# Altium schematic sheet Debug.SchDoc(Debug)
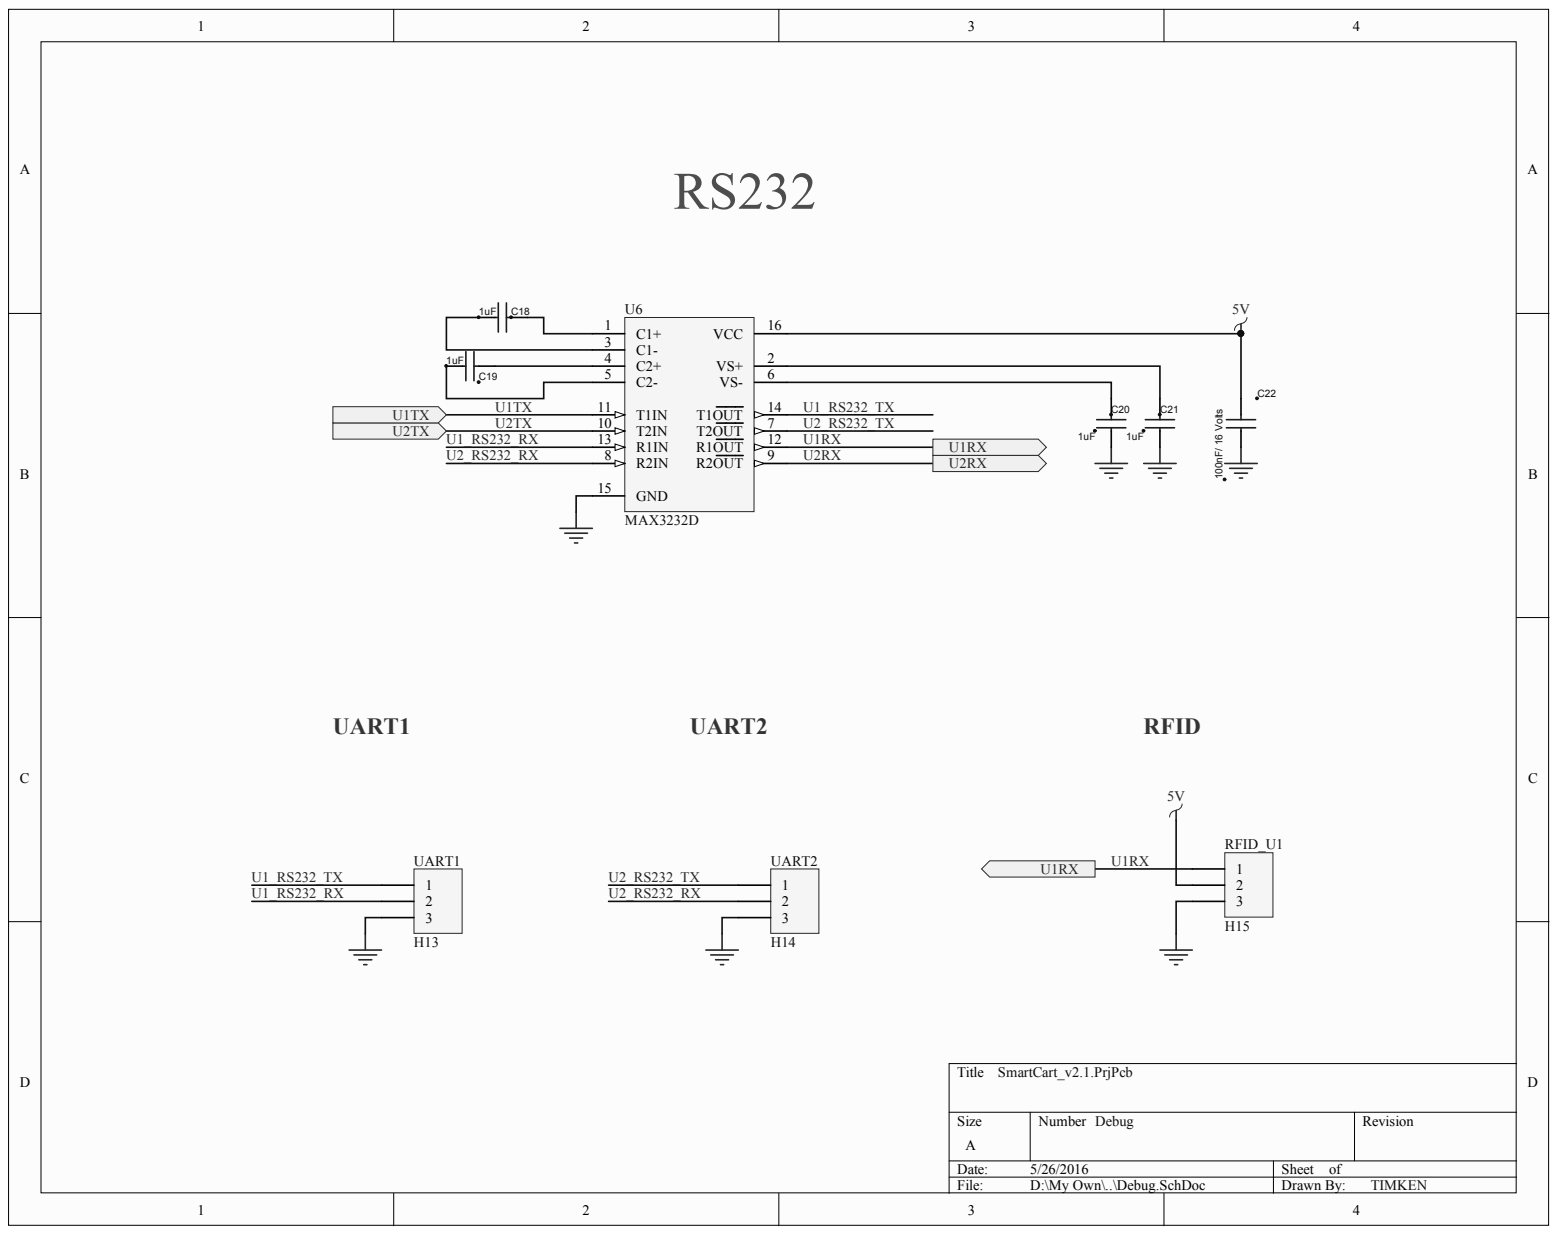
[diagram p0: Debug.SchDoc]

## Parts
C18  1uF  [C0805]
C19  1uF  [C0805]
C20  1uF  [C0805]
C21  1uF  [C0805]
C22  100nF/ 16 Volts  [C0805]
RFID_U1  H15  [1x3_single - duplicate]
U6  MAX3232D  [MAX232D]
UART1  H13  [1x3_single - duplicate]
UART2  H14  [1x3_single - duplicate]

## Ports
U1RX, U1TX, U2RX, U2TX

## Nets
5V: C22.2 RFID_U1.2 U6.16(VCC)
GND_POWER: C20.1 C21.1 C22.1 RFID_U1.3 U6.15(GND) UART1.3 UART2.3
U1_RS232_RX: U6.13(R1IN) UART1.2
U1_RS232_TX: U6.14(T1OUT) UART1.1
U1RX: RFID_U1.1 U6.12(R1OUT) ; port U1RX
U1TX: U6.11(T1IN) ; port U1TX
U2_RS232_RX: U6.8(R2IN) UART2.2
U2_RS232_TX: U6.7(T2OUT) UART2.1
U2RX: U6.9(R2OUT) ; port U2RX
U2TX: U6.10(T2IN) ; port U2TX
NetC18_1: C18.1 U6.3(C1-)
NetC18_2: C18.2 U6.1(C1+)
NetC19_1: C19.1 U6.5(C2-)
NetC19_2: C19.2 U6.4(C2+)
NetC20_2: C20.2 U6.6(VS-)
NetC21_2: C21.2 U6.2(VS+)

## Library data of the parts
C18-C22 = CAP NP: Descr: CAPACITOR, 0805, 100NF, 16V, X7R; M P No: CC0805KRX7R7BB104; Vendor: Farnell; V P No: 644160RL; Component Kind: Standard; Library Name: combined_cart_schematic_lib.SchLib
RFID_U1 UART1 UART2 = Header 3: Header, 3-Pin; Component Kind: Standard; LatestRevisionDate: 17-Jul-2002; LatestRevisionNote: Re-released for DXP Platform.; Library Name: combined_cart_schematic_lib.SchLib; Publisher: Altium Limited
U6 = MAX232D: Dual EIA-232 Driver/Receiver; Note: Receivers have typical hysteresis of 0.5V; Code_IPC: SOIC127P600-16; Component Kind: Standard; ComponentLink1Description: Manufacturer Link; ComponentLink1URL: http://www.ti.com/; ComponentLink2Description: Datasheet; ComponentLink2URL: http://www-s.ti.com/sc/ds/max232.pdf; DatasheetVersion: Jun-1989; LatestRevisionDate: 13-Apr-2006; LatestRevisionNote: IPC-7351 Footprint Added.; PackageDescription: 16-Pin Small Outline Integrated Circuit 1.27 mm Pitch; PackageReference: D016; PackageVersion: Jan-1998; Published: 15-May-2002; Library Name: combined_cart_schematic_lib.SchLib; Publisher: Altium Limited
